# Altium schematic sheet EXPORT.SchDoc(EXPORT)
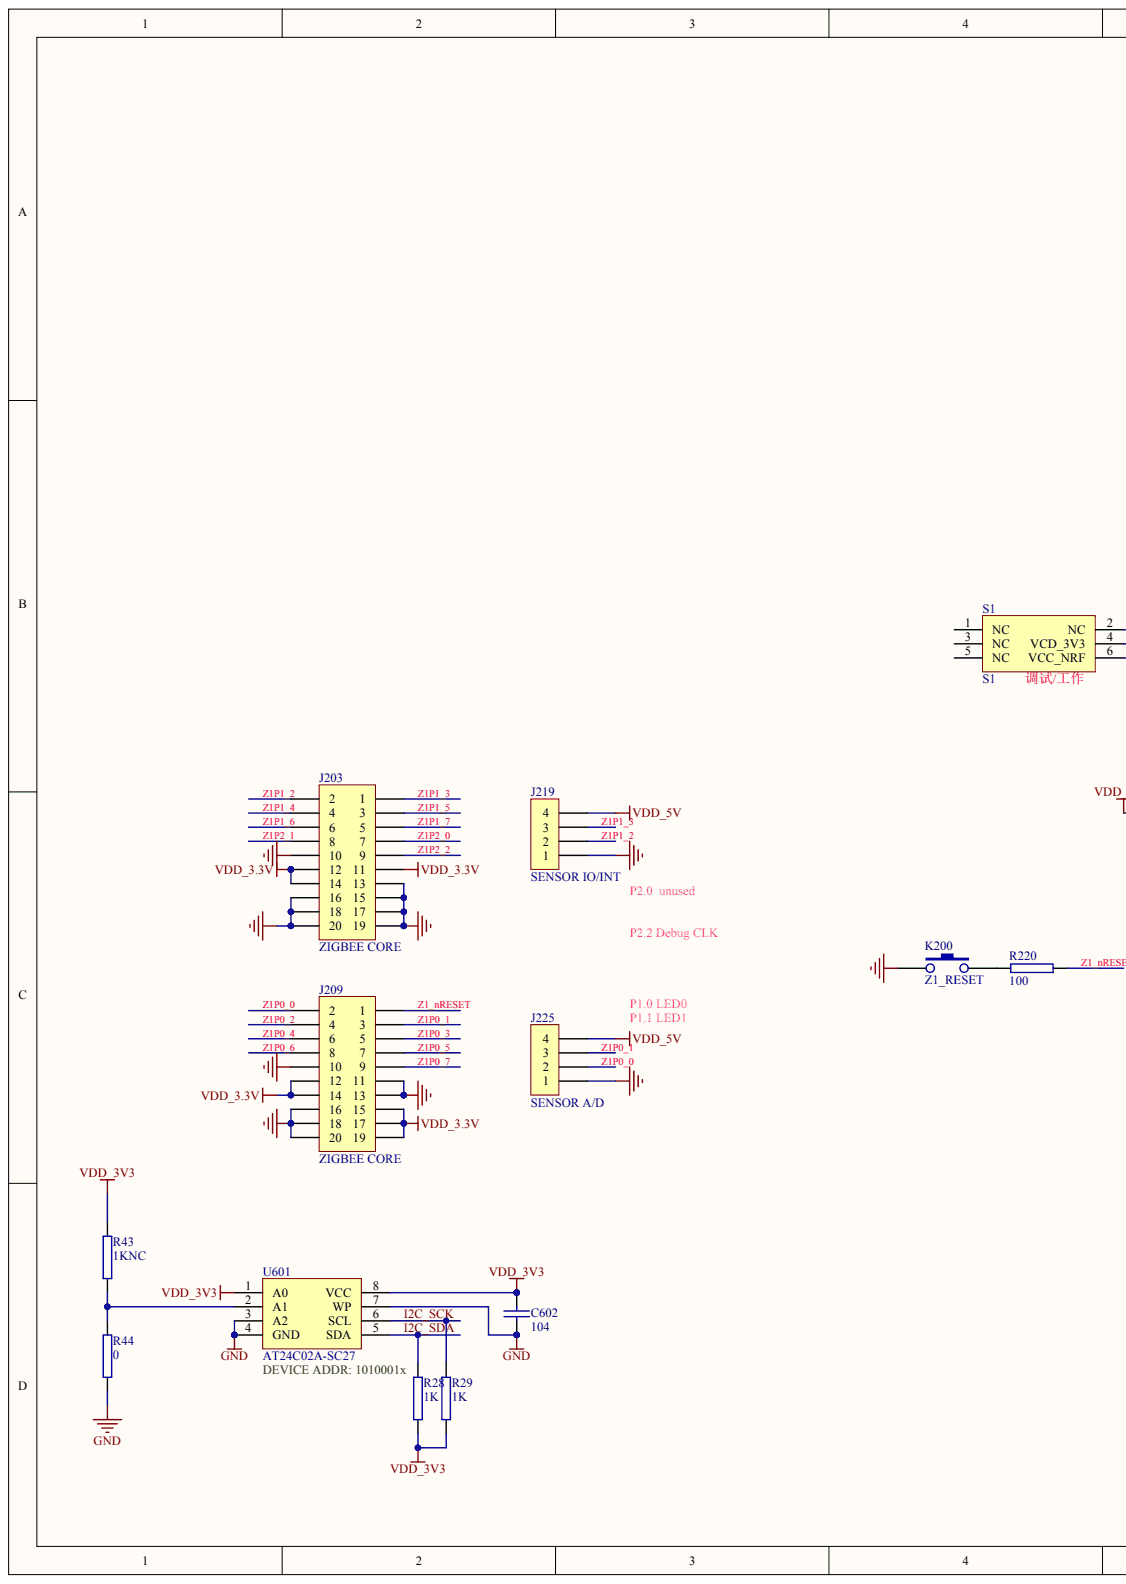
[diagram p0: EXPORT.SchDoc, left]
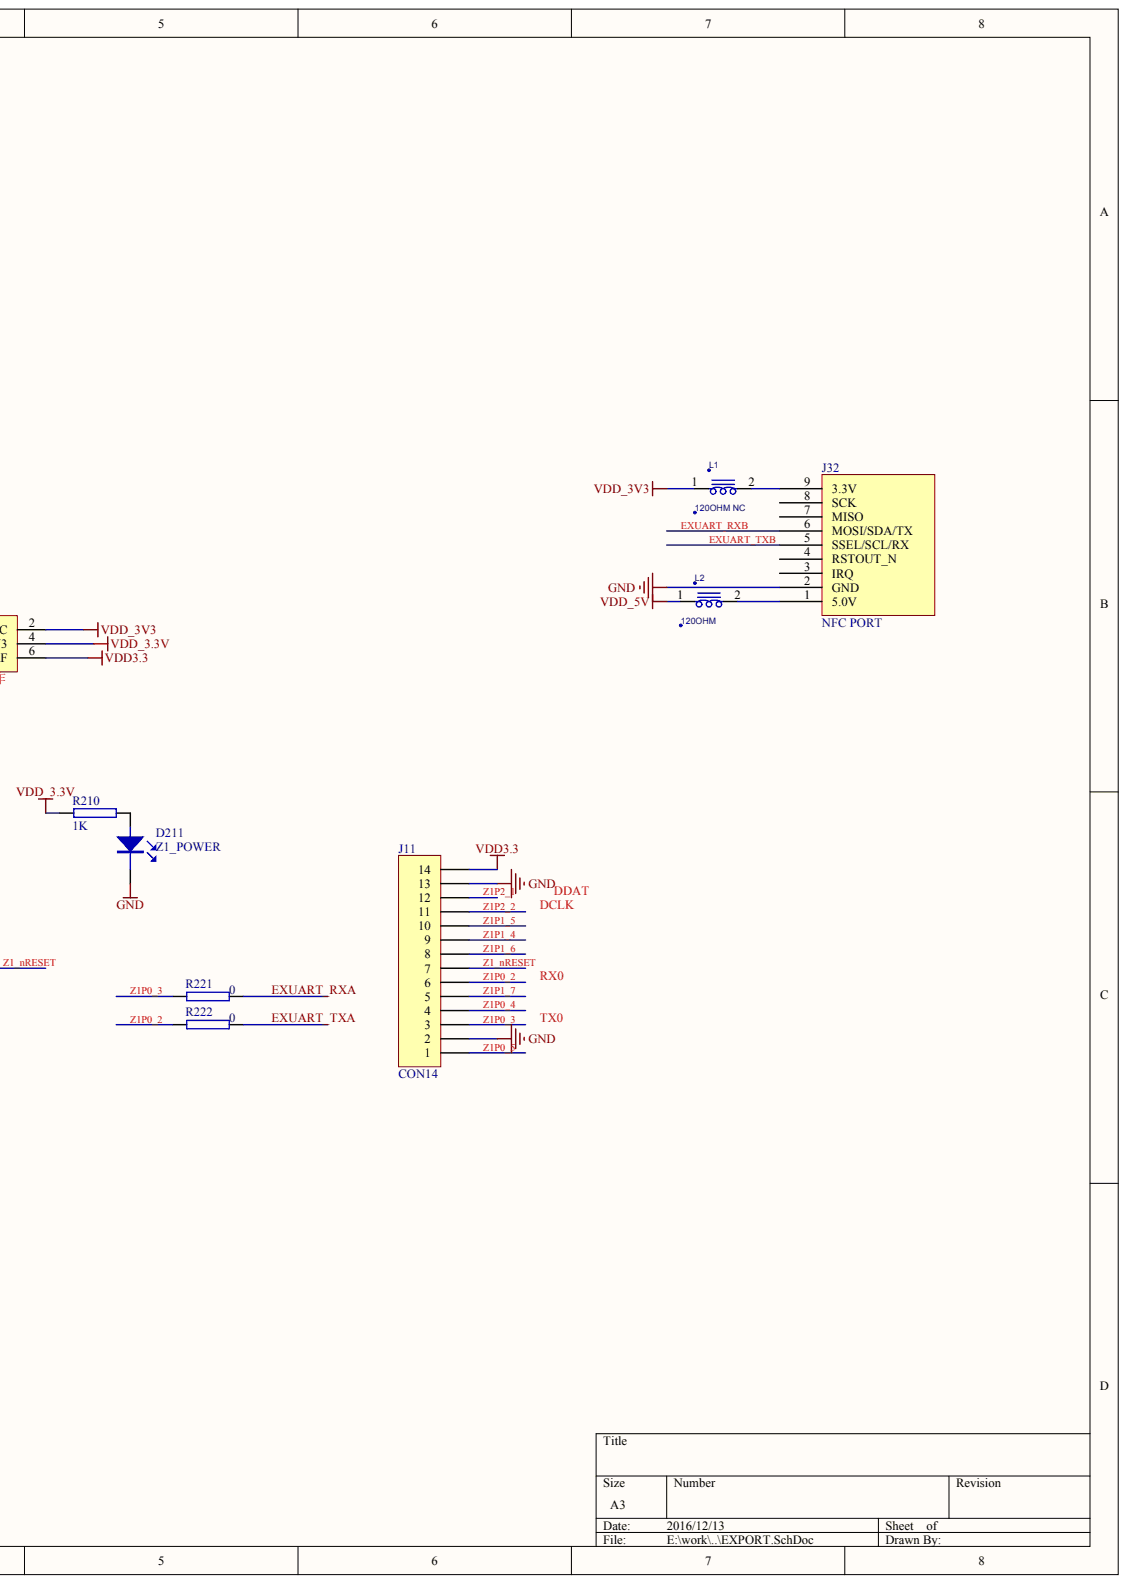
[diagram p1: EXPORT.SchDoc, right]

## Parts
C602  104
D211  Z1_POWER
J11  CON14
J32  NFC PORT
J203  ZIGBEE CORE
J209  ZIGBEE CORE
J219  SENSOR IO/INT
J225  SENSOR A/D
K200  Z1_RESET
L1  120OHM NC
L2  120OHM
R28  1K
R29  1K
R43  1KNC
R44  0
R210  1K
R220  100
R221  0
R222  0
S1  S1
U601  AT24C02A-SC27

## Nets
GND: C602.2 D211.2 J11.2 J11.13 J32.2(GND) J203.10 J203.13 J203.15 J203.16 J203.17 J203.18 J203.19 J203.20 J209.10 J209.11 J209.13 J209.16 J209.18 J209.20 J219.1 J225.1 K200.1 R44.2 U601.3(A2) U601.4(GND) U601.7(WP)
VDD3.3: J11.14 S1.6(VCC_NRF)
VDD_3.3V: J203.11 J203.12 J203.14 J209.12 J209.14 J209.15 J209.17 J209.19 R210.1 S1.4(VCD_3V3)
VDD_3V3: C602.1 L1.1 R28.2 R29.2 R43.1 S1.2(NC) U601.1(A0) U601.8(VCC)
VDD_5V: J219.4 J225.4 L2.1
EXUART_RXA: R221.1
EXUART_RXB: J32.6(MOSI/SDA/TX)
EXUART_TXA: R222.1
EXUART_TXB: J32.5(SSEL/SCL/RX)
I2C_SCK: R29.1 U601.6(SCL)
I2C_SDA: R28.1 U601.5(SDA)
Z1_NRESET: J11.7 J209.1 R220.1
Z1P0_0: J209.2 J225.2
Z1P0_1: J209.3 J225.3
Z1P0_2: J11.6 J209.4 R222.2
Z1P0_3: J11.3 J209.5 R221.2
Z1P0_4: J11.4 J209.6
Z1P0_5: J11.1 J209.7
Z1P0_6: J209.8
Z1P0_7: J209.9
Z1P1_2: J203.2 J219.2
Z1P1_3: J203.1 J219.3
Z1P1_4: J11.9 J203.4
Z1P1_5: J11.10 J203.3
Z1P1_6: J11.8 J203.6
Z1P1_7: J11.5 J203.5
Z1P2_0: J203.7
Z1P2_1: J11.12 J203.8
Z1P2_2: J11.11 J203.9
NetD211_1: D211.1 R210.2
NetJ32_1: J32.1(5.0V) L2.2
NetJ32_9: J32.9(3.3V) L1.2
NetK200_2: K200.2 R220.2
NetR43_2: R43.2 R44.1 U601.2(A1)

Not connected: J32 3(IRQ) 4(RSTOUT_N) 7(MISO) 8(SCK); S1 1(NC) 3(NC) 5(NC)

## Library data of the parts
L1 L2 = (no symbol name): PART_TYPE: FERRITE; CLASS: DISCRETE; Tolerance: 25%; PRIORITY_PN: 024-00067; MFG_NAME01: MURATA; CAD_APPROVED: Yes; FPLIST: IND_0603,0603_CC; AGILE_PN: 180-30008; MFG_PN01: BLM18PG121SH1; ROOM: RGMII_PHY; HEIGHT: 0.95MM; ROHS: Yes
